# Altium schematic sheet ZL-KPZ32_V3.SCHDOC
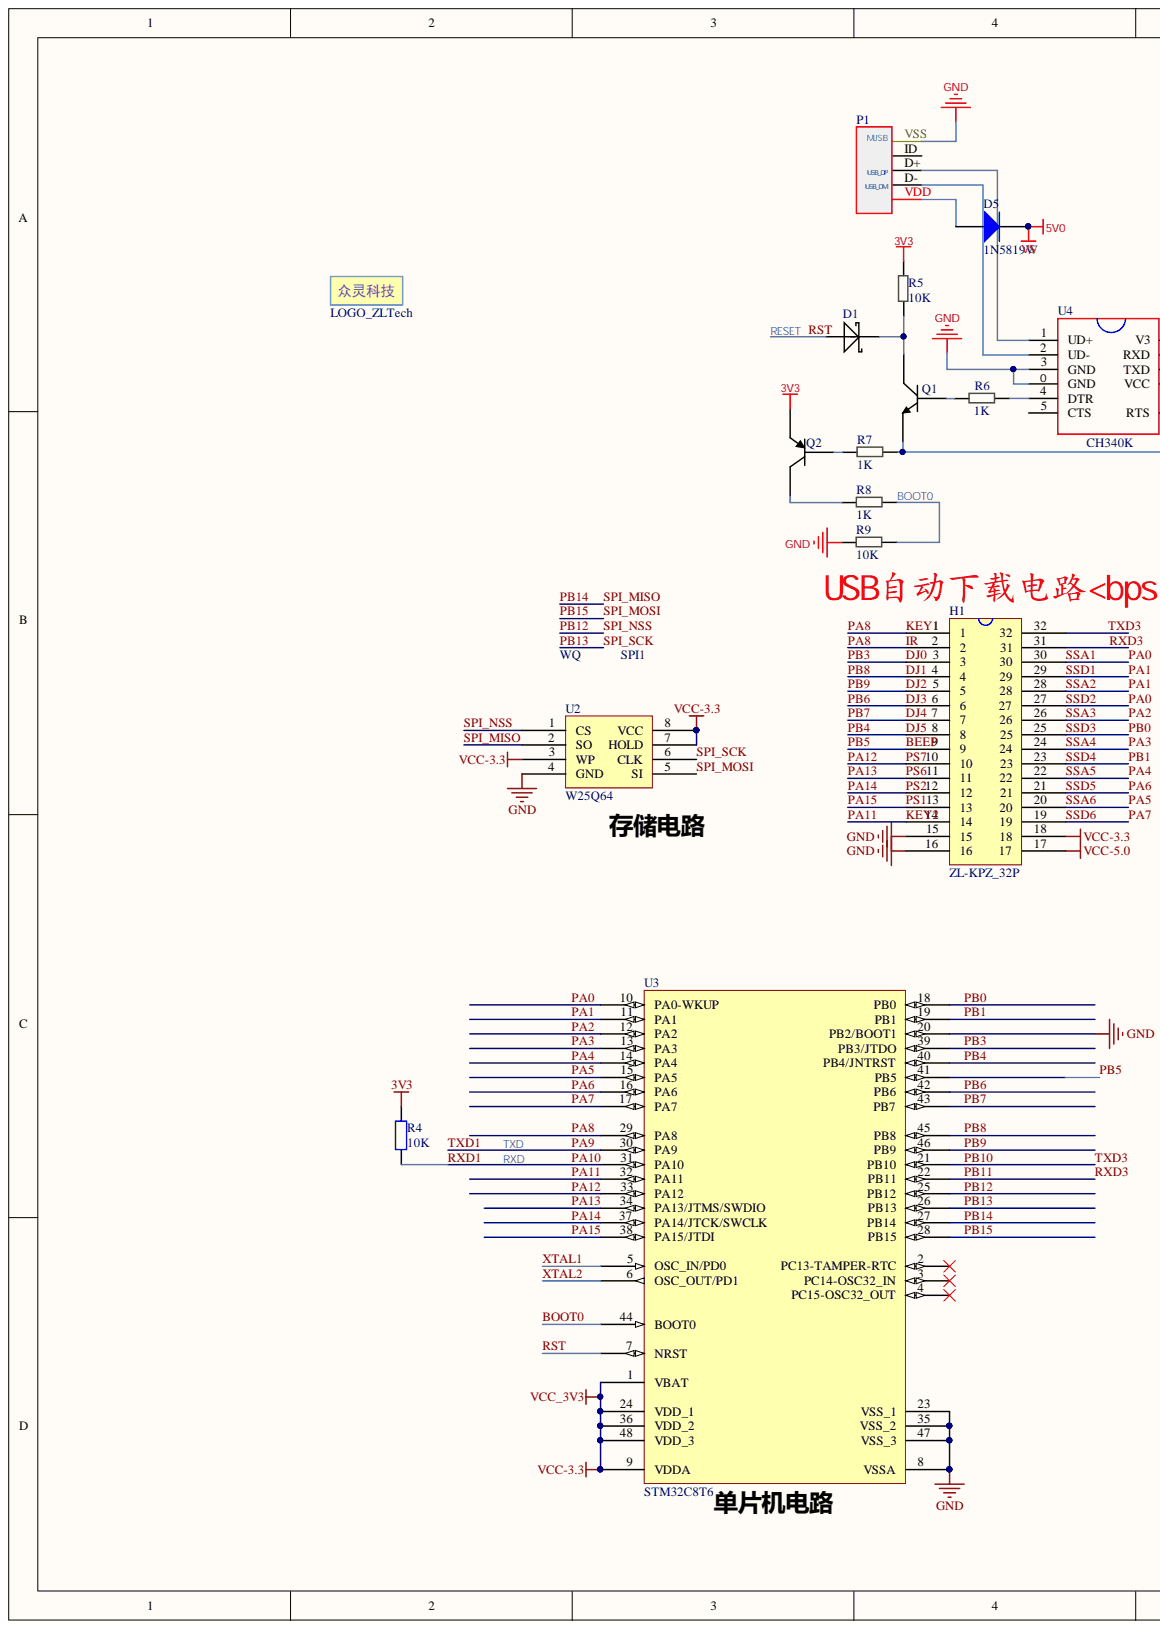
[diagram p0: ZL-KPZ32_V3.SCHDOC, left]
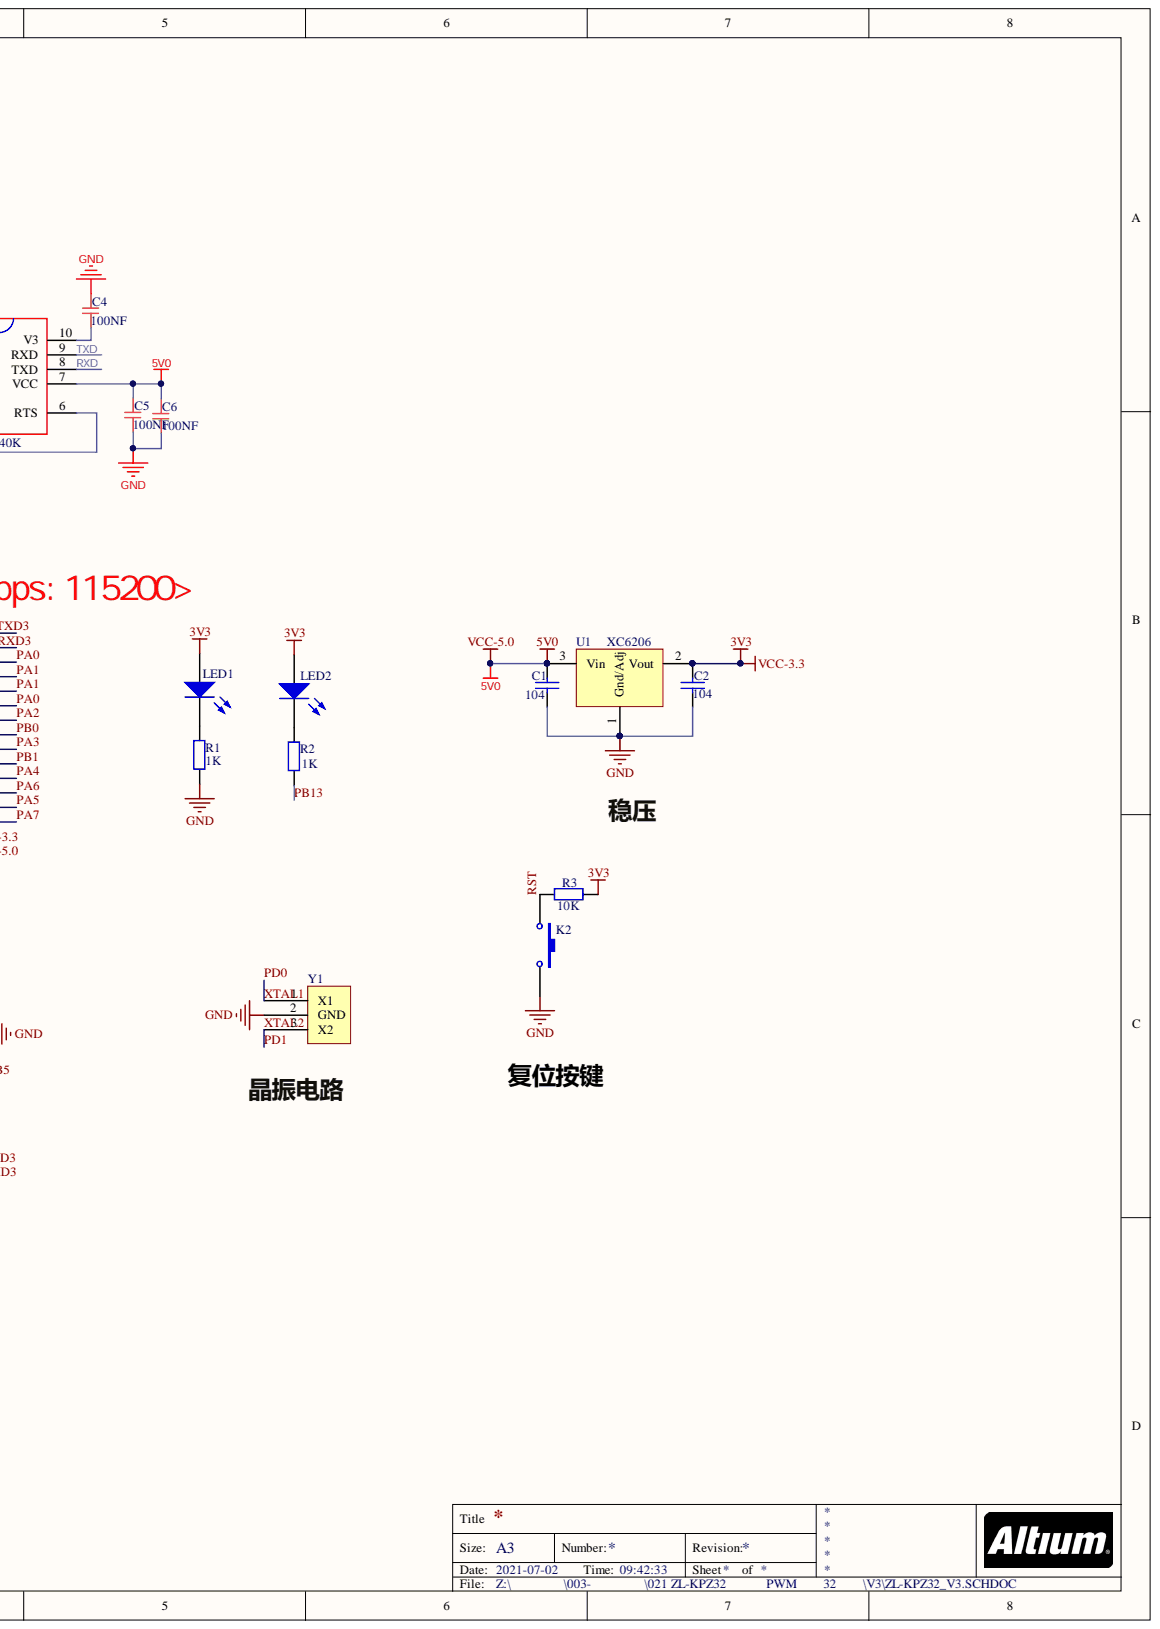
[diagram p1: ZL-KPZ32_V3.SCHDOC, right]

## Parts
C1  104  [C0603]
C2  104  [C0603]
C4  100NF  [C0603]
C5  100NF  [C0603]
C6  100NF  [C0603]
D1  D_S4  [D_SOD-123]  (value not drawn)
D5  1N5819W  [D_SOD-123]
H1  ZL-KPZ_32P  [ZL-KPZ_32P]
K2  贴片按键-白色  [KEY-MINI2]  (value not drawn)
LED1  LED-红色  [LED-0603]  (value not drawn)
LED2  LED-蓝色  [LED-0603]  (value not drawn)
LOGO  LOGO_ZLTech  [LOGO_ZL2+T]
P1  USB_Micro  [USB_Micro_2]  (value not drawn)
Q1  BJT_S8050  [SOT-23-3 (BJT)]  (value not drawn)
Q2  BJT_S8550  [SOT-23-3 (BJT)]  (value not drawn)
R1  1K  [R0603]
R2  1K  [R0603]
R3  10K  [R0603]
R4  10K  [R0603]
R5  10K  [R0603]
R6  1K  [R0603]
R7  1K  [R0603]
R8  1K  [R0603]
R9  10K  [R0603]
U1  XC6206  [SOT-23-3 2]
U2  W25Q64  [SOP8-Width]
U3  STM32C8T6  [LQFP48 7X7_L]
U4  CH340K  [ESSOP10]
Y1  8M无源晶振3脚  [XTAL_SMD-CSTSE800G52-R0]  (value not drawn)

## Nets
3V3: C2.1 H1.18 LED1.1 LED2.1 Q2.E R3.1 R4.1 R5.2 U1.2 U2.3(WP) U2.7(HOLD) U2.8(VCC) U3.1(VBAT) U3.9(VDDA) U3.24(VDD_1) U3.36(VDD_2) U3.48(VDD_3)
5V0: C1.2 C5.1 C6.1 D5.2 H1.17 U1.3 U4.7(VCC)
GND: C1.1 C2.2 C4.1 C5.2 C6.2 H1.15 H1.16 K2.2 P1.VSS(MUSB) R1.1 R9.1 U1.1 U2.4(GND) U3.8(VSSA) U3.20(PB2/BOOT1) U3.23(VSS_1) U3.35(VSS_2) U3.47(VSS_3) U4.0(GND) U4.3(GND) Y1.2
BEEP: H1.9 U3.41(PB5)
BOOT0: R8.2 R9.2 U3.44(BOOT0)
DJ0: H1.3 U3.39(PB3/JTDO)
DJ1: H1.4 U3.45(PB8)
DJ2: H1.5 U3.46(PB9)
DJ3: H1.6 U3.42(PB6)
DJ4: H1.7 U3.43(PB7)
DJ5: H1.8 U3.40(PB4/JNTRST)
IR: H1.1 H1.2 U3.29(PA8)
KEY2: H1.14 U3.32(PA11)
PA0: H1.27 H1.30 U3.10(PA0-WKUP)
PA1: H1.28 H1.29 U3.11(PA1)
PA2: H1.26 U3.12(PA2)
PA3: H1.24 U3.13(PA3)
PA4: H1.22 U3.14(PA4)
PA5: H1.20 U3.15(PA5)
PA6: H1.21 U3.16(PA6)
PA7: H1.19 U3.17(PA7)
PA9: U3.30(PA9) U4.9(RXD)
PA10: R4.2 U3.31(PA10) U4.8(TXD)
PA12: H1.10 U3.33(PA12)
PA13: H1.11 U3.34(PA13/JTMS/SWDIO)
PA14: H1.12 U3.37(PA14/JTCK/SWCLK)
PA15: H1.13 U3.38(PA15/JTDI)
PB0: H1.25 U3.18(PB0)
PB1: H1.23 U3.19(PB1)
PB3: U3.39(PB3/JTDO)
PB4: U3.40(PB4/JNTRST)
PB5: U3.41(PB5)
PB6: U3.42(PB6)
PB7: U3.43(PB7)
PB8: U3.45(PB8)
PB9: U3.46(PB9)
PB10: H1.32 U3.21(PB10)
PB11: H1.31 U3.22(PB11)
PB12: U2.1(CS) U3.25(PB12)
PB13: R2.1 U2.6(CLK) U3.26(PB13)
PB14: U2.2(SO) U3.27(PB14)
PB15: U2.5(SI) U3.28(PB15)
PD0: U3.5(OSC_IN/PD0) Y1.1
PD1: U3.6(OSC_OUT/PD1) Y1.3
RESET: D1.1 K2.1 R3.2 U3.7(NRST)
RST: K2.1 R3.2
RXD: U4.8(TXD)
RXD3: H1.31
TXD: U4.9(RXD)
TXD3: H1.32
NetC4_2: C4.2 U4.10(V3)
NetD1_2: D1.2 Q1.C R5.1
NetD5_1: D5.1 P1.VDD
NetLED1_2: LED1.2 R1.2
NetLED2_2: LED2.2 R2.2
NetP1_D+: P1.D+ U4.1(UD+)
NetP1_D-: P1.D- U4.2(UD-)
NetQ1_B: Q1.B R6.1
NetQ1_E: Q1.E R7.2 U4.6(RTS)
NetQ2_B: Q2.B R7.1
NetQ2_C: Q2.C R8.1
NetR6_2: R6.2 U4.4(DTR)

Not connected: P1 ID; U3 2(PC13-TAMPER-RTC) 3(PC14-OSC32_IN) 4(PC15-OSC32_OUT); U4 5(CTS)

## Library data of the parts
C1 C2 = Cap: Capacitor; Component Kind: Standard; LatestRevisionDate: 17-Jul-2002; LatestRevisionNote: Re-released for DXP Platform.; Library Name: Miscellaneous Devices.IntLib; PackageReference: RAD-0.3; Published: 8-Jun-2000; Signal Integrity: Cap; Simulation: CAP; Publisher: Altium Limited
C4-C6 = Cap Disk 0603: 贴片 C0603 50V100NF; Component Kind: Standard; Library Name: TechMan.SchLib
D1 = D_S4: 贴片二极管 5819 SL; Code_JEDEC: DO-214-AB; Component Kind: Standard; Library Name: TechMan.SchLib; PackageDescription: DO-214-AB/SMC; 2 C-Bend Leads; Body 7.9 x 5.9 mm, inc. leads (LxW); PackageVersion: Sep-1996
D5 = Diode: 贴片二极管 S4 SOD-323; Code_JEDEC: DO-214-AB; Component Kind: Standard; LatestRevisionDate: 17-Jul-2002; LatestRevisionNote: Re-released for DXP Platform.; PackageDocument: Sep-1996; PackageReference: SMC; Published: 8-Jun-2000; Signal Integrity: Diode; Simulation: DIODE; Library Name: SPP_V1.SCHLIB; Publisher: Altium Limited
K2 = SW-PB: Component Kind: Standard; Library Name: arduinoV1.IntLib
LED1 LED2 = LED: Component Kind: Standard; Library Name: MY-SCH.SCHLIB
P1 = USB_Micro: MicroUSB母头; Component Kind: Standard; Library Name: TechMan.SchLib
Q1 = BJT_S8050: NPN型贴片三极管; Component Kind: Standard; ComponentLink1Description: Manufacturer Link; ComponentLink1URL: http://www.fairchildsemi.com/; ComponentLink2Description: Datasheet; ComponentLink2URL: http://www.fairchildsemi.com/ds/2N%2F2N3906.pdf; DatasheetVersion: 1997; LatestRevisionDate: 15-Jan-2003; LatestRevisionNote: PCB Footprint 'BCY-W3/D4.7' replaced; Library Name: TechMan.SchLib; PackageDescription: TO, Flat Index; 3 In-Line, Axial Leads; Body Dia. 4.5mm; Leads 0.51 x 0.48 mm (max); PackageReference: TO-92A; PackageVersion: Sep-1998; Published: 8-Jun-2000; Publisher: Altium Limited
Q2 = BJT_S8550: PNP型贴片三极管; Component Kind: Standard; ComponentLink1Description: Manufacturer Link; ComponentLink1URL: http://www.fairchildsemi.com/; ComponentLink2Description: Datasheet; ComponentLink2URL: http://www.fairchildsemi.com/ds/2N%2F2N3906.pdf; DatasheetVersion: 1997; LatestRevisionDate: 15-Jan-2003; LatestRevisionNote: PCB Footprint 'BCY-W3/D4.7' replaced; Library Name: TechMan.SchLib; PackageDescription: TO, Flat Index; 3 In-Line, Axial Leads; Body Dia. 4.5mm; Leads 0.51 x 0.48 mm (max); PackageReference: TO-92A; PackageVersion: Sep-1998; Published: 8-Jun-2000; Publisher: Altium Limited
R1-R4 = Res2: Resistor; Component Kind: Standard; LatestRevisionDate: 17-Jul-2002; LatestRevisionNote: Re-released for DXP Platform.; Library Name: Miscellaneous Devices.IntLib; PackageReference: AXIAL-0.4; Published: 8-Jun-2000; Signal Integrity: Res; Simulation: RESISTOR; Publisher: Altium Limited
  R3 R4: Value: 10K
R5-R9 = R0603: Component Kind: Standard; Description: R0603; Library Name: TechMan.SchLib
U1 = AMS1117: Component Kind: Standard; Library Name: MY-SCH.SCHLIB
U3 = STM32F103C8T6: Component Kind: Standard; Library Name: 2013机器人队元件标准库.SchLib
U4 = CH340K: ESSOP10; Component Kind: Standard; Library Name: TechMan.SchLib
Y1 = xtal2: Component Kind: Standard; Library Name: MY-SCH.SCHLIB
H1 = ZL-KPZ_32P: Component Kind: Standard; Library Name: MY-SCH.SCHLIB
LOGO = LOGO_ZLTech: Component Kind: Standard; Library Name: TechMan.SchLib
U2 = W25Q64: Component Kind: Standard; Library Name: MY-SCH.SCHLIB
